# Altium schematic sheet DRAM.SchDoc(DRAM)
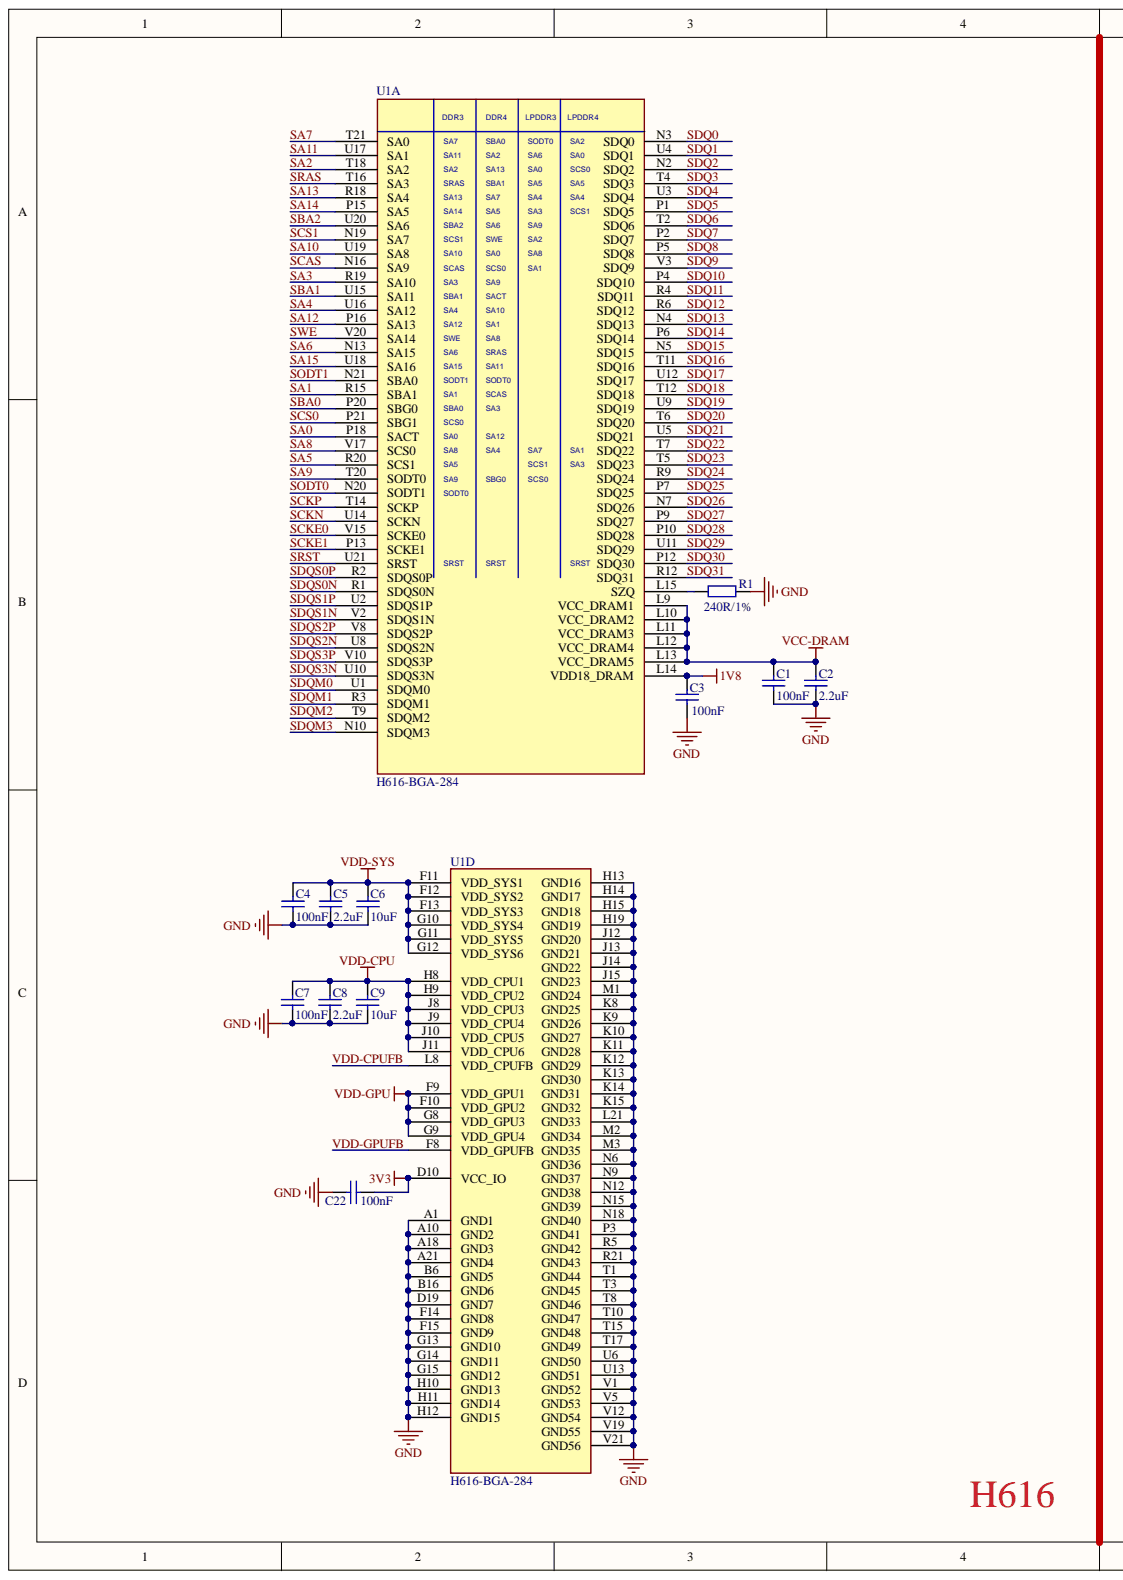
[diagram p0: DRAM.SchDoc, left]
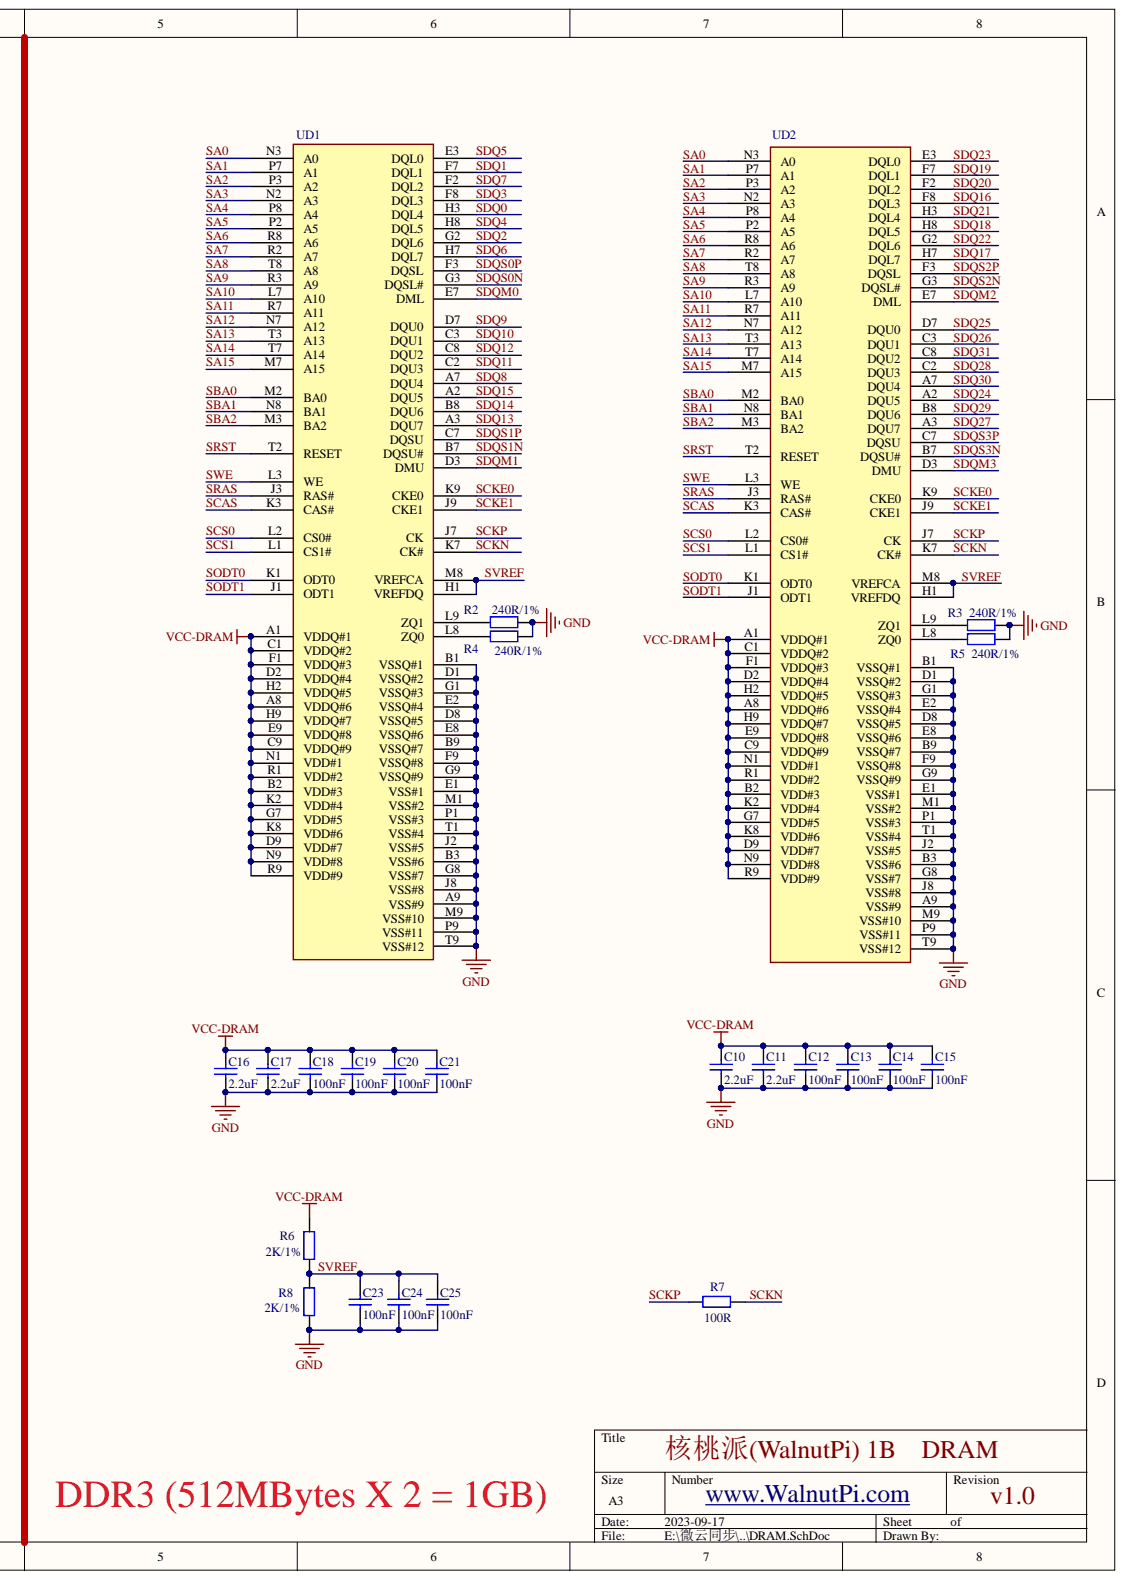
[diagram p1: DRAM.SchDoc, right]

## Parts
C1  100nF  [R0402]
C2  2.2uF  [R0402]
C3  100nF  [R0402]
C4  100nF  [R0402]
C5  2.2uF  [R0402]
C6  10uF  [R0402]
C7  100nF  [R0402]
C8  2.2uF  [R0402]
C9  10uF  [R0402]
C10  2.2uF  [R0402]
C11  2.2uF  [R0402]
C12  100nF  [R0402]
C13  100nF  [R0402]
C14  100nF  [R0402]
C15  100nF  [R0402]
C16  2.2uF  [R0402]
C17  2.2uF  [R0402]
C18  100nF  [R0402]
C19  100nF  [R0402]
C20  100nF  [R0402]
C21  100nF  [R0402]
C22  100nF  [R0402]
C23  100nF  [R0402]
C24  100nF  [R0402]
C25  100nF  [R0402]
R1  240R/1%  [R0402]
R2  240R/1%  [R0402]
R3  240R/1%  [R0402]
R4  240R/1%  [R0402]
R5  240R/1%  [R0402]
R6  2K/1%  [R0402]
R7  100R  [R0402]
R8  2K/1%  [R0402]
U1  H616-BGA-284  [H616-BGA-284]  (parts A,D)
UD1  (no value)  [FBGA-96_L14.0-W8.0-R16-C6-P0.80-TL]
UD2  (no value)  [FBGA-96_L14.0-W8.0-R16-C6-P0.80-TL]

## Nets
1V8: C3.2 U1.L14(VDD18_DRAM)
3V3: C22.1 U1.D10(VCC_IO)
GND: C1.1 C2.1 C3.1 C4.1 C5.1 C6.1 C7.1 C8.1 C9.1 C10.1 C11.1 C12.1 C13.1 C14.1 C15.1 C16.1 C17.1 C18.1 C19.1 C20.1 C21.1 C22.2 C23.1 C24.1 C25.1 R1.1 R2.1 R3.1 R4.1 R5.1 R8.1 U1.A1(GND1) U1.A10(GND2) U1.A18(GND3) U1.A21(GND4) U1.B6(GND5) U1.B16(GND6) U1.D19(GND7) U1.F14(GND8) U1.F15(GND9) U1.G13(GND10) U1.G14(GND11) U1.G15(GND12) U1.H10(GND13) U1.H11(GND14) U1.H12(GND15) U1.H13(GND16) U1.H14(GND17) U1.H15(GND18) U1.H19(GND19) U1.J12(GND20) U1.J13(GND21) U1.J14(GND22) U1.J15(GND23) U1.K8(GND25) U1.K9(GND26) U1.K10(GND27) U1.K11(GND28) U1.K12(GND29) U1.K13(GND30) U1.K14(GND31) U1.K15(GND32) U1.L21(GND33) U1.M1(GND24) U1.M2(GND34) U1.M3(GND35) U1.N6(GND36) U1.N9(GND37) U1.N12(GND38) U1.N15(GND39) U1.N18(GND40) U1.P3(GND41) U1.R5(GND42) U1.R21(GND43) U1.T1(GND44) U1.T3(GND45) U1.T8(GND46) U1.T10(GND47) U1.T15(GND48) U1.T17(GND49) U1.U6(GND50) U1.U13(GND51) U1.V1(GND52) U1.V5(GND53) U1.V12(GND54) U1.V19(GND55) U1.V21(GND56) UD1.A9(VSS#9) UD1.B1(VSSQ#1) UD1.B3(VSS#6) UD1.B9(VSSQ#7) UD1.D1(VSSQ#2) UD1.D8(VSSQ#5) UD1.E1(VSS#1) UD1.E2(VSSQ#4) UD1.E8(VSSQ#6) UD1.F9(VSSQ#8) UD1.G1(VSSQ#3) UD1.G8(VSS#7) UD1.G9(VSSQ#9) UD1.J2(VSS#5) UD1.J8(VSS#8) UD1.M1(VSS#2) UD1.M9(VSS#10) UD1.P1(VSS#3) UD1.P9(VSS#11) UD1.T1(VSS#4) UD1.T9(VSS#12) UD2.A9(VSS#9) UD2.B1(VSSQ#1) UD2.B3(VSS#6) UD2.B9(VSSQ#7) UD2.D1(VSSQ#2) UD2.D8(VSSQ#5) UD2.E1(VSS#1) UD2.E2(VSSQ#4) UD2.E8(VSSQ#6) UD2.F9(VSSQ#8) UD2.G1(VSSQ#3) UD2.G8(VSS#7) UD2.G9(VSSQ#9) UD2.J2(VSS#5) UD2.J8(VSS#8) UD2.M1(VSS#2) UD2.M9(VSS#10) UD2.P1(VSS#3) UD2.P9(VSS#11) UD2.T1(VSS#4) UD2.T9(VSS#12)
VCC-DRAM: C1.2 C2.2 C10.2 C11.2 C12.2 C13.2 C14.2 C15.2 C16.2 C17.2 C18.2 C19.2 C20.2 C21.2 R6.1 U1.L9(VCC_DRAM1) U1.L10(VCC_DRAM2) U1.L11(VCC_DRAM3) U1.L12(VCC_DRAM4) U1.L13(VCC_DRAM5) UD1.A1(VDDQ#1) UD1.A8(VDDQ#6) UD1.B2(VDD#3) UD1.C1(VDDQ#2) UD1.C9(VDDQ#9) UD1.D2(VDDQ#4) UD1.D9(VDD#7) UD1.E9(VDDQ#8) UD1.F1(VDDQ#3) UD1.G7(VDD#5) UD1.H2(VDDQ#5) UD1.H9(VDDQ#7) UD1.K2(VDD#4) UD1.K8(VDD#6) UD1.N1(VDD#1) UD1.N9(VDD#8) UD1.R1(VDD#2) UD1.R9(VDD#9) UD2.A1(VDDQ#1) UD2.A8(VDDQ#6) UD2.B2(VDD#3) UD2.C1(VDDQ#2) UD2.C9(VDDQ#9) UD2.D2(VDDQ#4) UD2.D9(VDD#7) UD2.E9(VDDQ#8) UD2.F1(VDDQ#3) UD2.G7(VDD#5) UD2.H2(VDDQ#5) UD2.H9(VDDQ#7) UD2.K2(VDD#4) UD2.K8(VDD#6) UD2.N1(VDD#1) UD2.N9(VDD#8) UD2.R1(VDD#2) UD2.R9(VDD#9)
VDD-CPU: C7.2 C8.2 C9.2 U1.H8(VDD_CPU1) U1.H9(VDD_CPU2) U1.J8(VDD_CPU3) U1.J9(VDD_CPU4) U1.J10(VDD_CPU5) U1.J11(VDD_CPU6)
VDD-GPU: U1.F9(VDD_GPU1) U1.F10(VDD_GPU2) U1.G8(VDD_GPU3) U1.G9(VDD_GPU4)
VDD-SYS: C4.2 C5.2 C6.2 U1.F11(VDD_SYS1) U1.F12(VDD_SYS2) U1.F13(VDD_SYS3) U1.G10(VDD_SYS4) U1.G11(VDD_SYS5) U1.G12(VDD_SYS6)
SA0: U1.P18(SACT) UD1.N3(A0) UD2.N3(A0)
SA1: U1.R15(SBA1) UD1.P7(A1) UD2.P7(A1)
SA2: U1.T18(SA2) UD1.P3(A2) UD2.P3(A2)
SA3: U1.R19(SA10) UD1.N2(A3) UD2.N2(A3)
SA4: U1.U16(SA12) UD1.P8(A4) UD2.P8(A4)
SA5: U1.R20(SCS1) UD1.P2(A5) UD2.P2(A5)
SA6: U1.N13(SA15) UD1.R8(A6) UD2.R8(A6)
SA7: U1.T21(SA0) UD1.R2(A7) UD2.R2(A7)
SA8: U1.V17(SCS0) UD1.T8(A8) UD2.T8(A8)
SA9: U1.T20(SODT0) UD1.R3(A9) UD2.R3(A9)
SA10: U1.U19(SA8) UD1.L7(A10) UD2.L7(A10)
SA11: U1.U17(SA1) UD1.R7(A11) UD2.R7(A11)
SA12: U1.P16(SA13) UD1.N7(A12) UD2.N7(A12)
SA13: U1.R18(SA4) UD1.T3(A13) UD2.T3(A13)
SA14: U1.P15(SA5) UD1.T7(A14) UD2.T7(A14)
SA15: U1.U18(SA16) UD1.M7(A15) UD2.M7(A15)
SBA0: U1.P20(SBG0) UD1.M2(BA0) UD2.M2(BA0)
SBA1: U1.U15(SA11) UD1.N8(BA1) UD2.N8(BA1)
SBA2: U1.U20(SA6) UD1.M3(BA2) UD2.M3(BA2)
SCAS: U1.N16(SA9) UD1.K3(CAS#) UD2.K3(CAS#)
SCKE0: U1.V15(SCKE0) UD1.K9(CKE0) UD2.K9(CKE0)
SCKE1: U1.P13(SCKE1) UD1.J9(CKE1) UD2.J9(CKE1)
SCKN: R7.1 U1.U14(SCKN) UD1.K7(CK#) UD2.K7(CK#)
SCKP: R7.2 U1.T14(SCKP) UD1.J7(CK) UD2.J7(CK)
SCS0: U1.P21(SBG1) UD1.L2(CS0#) UD2.L2(CS0#)
SCS1: U1.N19(SA7) UD1.L1(CS1#) UD2.L1(CS1#)
SDQ0: U1.N3(SDQ0) UD1.H3(DQL4)
SDQ1: U1.U4(SDQ1) UD1.F7(DQL1)
SDQ2: U1.N2(SDQ2) UD1.G2(DQL6)
SDQ3: U1.T4(SDQ3) UD1.F8(DQL3)
SDQ4: U1.U3(SDQ4) UD1.H8(DQL5)
SDQ5: U1.P1(SDQ5) UD1.E3(DQL0)
SDQ6: U1.T2(SDQ6) UD1.H7(DQL7)
SDQ7: U1.P2(SDQ7) UD1.F2(DQL2)
SDQ8: U1.P5(SDQ8) UD1.A7(DQU4)
SDQ9: U1.V3(SDQ9) UD1.D7(DQU0)
SDQ10: U1.P4(SDQ10) UD1.C3(DQU1)
SDQ11: U1.R4(SDQ11) UD1.C2(DQU3)
SDQ12: U1.R6(SDQ12) UD1.C8(DQU2)
SDQ13: U1.N4(SDQ13) UD1.A3(DQU7)
SDQ14: U1.P6(SDQ14) UD1.B8(DQU6)
SDQ15: U1.N5(SDQ15) UD1.A2(DQU5)
SDQ16: U1.T11(SDQ16) UD2.F8(DQL3)
SDQ17: U1.U12(SDQ17) UD2.H7(DQL7)
SDQ18: U1.T12(SDQ18) UD2.H8(DQL5)
SDQ19: U1.U9(SDQ19) UD2.F7(DQL1)
SDQ20: U1.T6(SDQ20) UD2.F2(DQL2)
SDQ21: U1.U5(SDQ21) UD2.H3(DQL4)
SDQ22: U1.T7(SDQ22) UD2.G2(DQL6)
SDQ23: U1.T5(SDQ23) UD2.E3(DQL0)
SDQ24: U1.R9(SDQ24) UD2.A2(DQU5)
SDQ25: U1.P7(SDQ25) UD2.D7(DQU0)
SDQ26: U1.N7(SDQ26) UD2.C3(DQU1)
SDQ27: U1.P9(SDQ27) UD2.A3(DQU7)
SDQ28: U1.P10(SDQ28) UD2.C2(DQU3)
SDQ29: U1.U11(SDQ29) UD2.B8(DQU6)
SDQ30: U1.P12(SDQ30) UD2.A7(DQU4)
SDQ31: U1.R12(SDQ31) UD2.C8(DQU2)
SDQM0: U1.U1(SDQM0) UD1.E7(DML)
SDQM1: U1.R3(SDQM1) UD1.D3(DMU)
SDQM2: U1.T9(SDQM2) UD2.E7(DML)
SDQM3: U1.N10(SDQM3) UD2.D3(DMU)
SDQS0N: U1.R1(SDQS0N) UD1.G3(DQSL#)
SDQS0P: U1.R2(SDQS0P) UD1.F3(DQSL)
SDQS1N: U1.V2(SDQS1N) UD1.B7(DQSU#)
SDQS1P: U1.U2(SDQS1P) UD1.C7(DQSU)
SDQS2N: U1.U8(SDQS2N) UD2.G3(DQSL#)
SDQS2P: U1.V8(SDQS2P) UD2.F3(DQSL)
SDQS3N: U1.U10(SDQS3N) UD2.B7(DQSU#)
SDQS3P: U1.V10(SDQS3P) UD2.C7(DQSU)
SODT0: U1.N20(SODT1) UD1.K1(ODT0) UD2.K1(ODT0)
SODT1: U1.N21(SBA0) UD1.J1(ODT1) UD2.J1(ODT1)
SRAS: U1.T16(SA3) UD1.J3(RAS#) UD2.J3(RAS#)
SRST: U1.U21(SRST) UD1.T2(RESET) UD2.T2(RESET)
SVREF: C23.2 C24.2 C25.2 R6.2 R8.2 UD1.H1(VREFDQ) UD1.M8(VREFCA) UD2.H1(VREFDQ) UD2.M8(VREFCA)
SWE: U1.V20(SA14) UD1.L3(WE) UD2.L3(WE)
VDD-CPUFB: U1.L8(VDD_CPUFB)
VDD-GPUFB: U1.F8(VDD_GPUFB)
NetR1_2: R1.2 U1.L15(SZQ)
NetR2_2: R2.2 UD1.L9(ZQ1)
NetR3_2: R3.2 UD2.L9(ZQ1)
NetR4_2: R4.2 UD1.L8(ZQ0)
NetR5_2: R5.2 UD2.L8(ZQ0)

## Library data of the parts
C1-C25 = Cap: Capacitor; Simulation: CAP; Signal Integrity: Cap; Published: 8-Jun-2000; PackageReference: RAD-0.3; Library Name: Miscellaneous Devices.IntLib; LatestRevisionNote: Re-released for DXP Platform.; LatestRevisionDate: 17-Jul-2002; Component Kind: Standard; Publisher: Altium Limited
R1-R8 = Res2: Resistor; Simulation: RESISTOR; Signal Integrity: Res; Published: 8-Jun-2000; PackageReference: AXIAL-0.4; Library Name: Miscellaneous Devices.IntLib; LatestRevisionNote: Re-released for DXP Platform.; LatestRevisionDate: 17-Jul-2002; Component Kind: Standard; Publisher: Altium Limited
U1 = H616-BGA-284: SWAP_INFO: (S1+S2+S3+S4); SPLIT_INST: TRUE; Library Name: Schlib1.SchLib; Component Kind: Standard
UD1 UD2 = DDR3-FBGA96: Parameter: DDR3-FBGA96; Library Name: Schlib1.SchLib; Component Kind: Standard
